# Altium schematic sheet [02] MainBoard.SchDoc
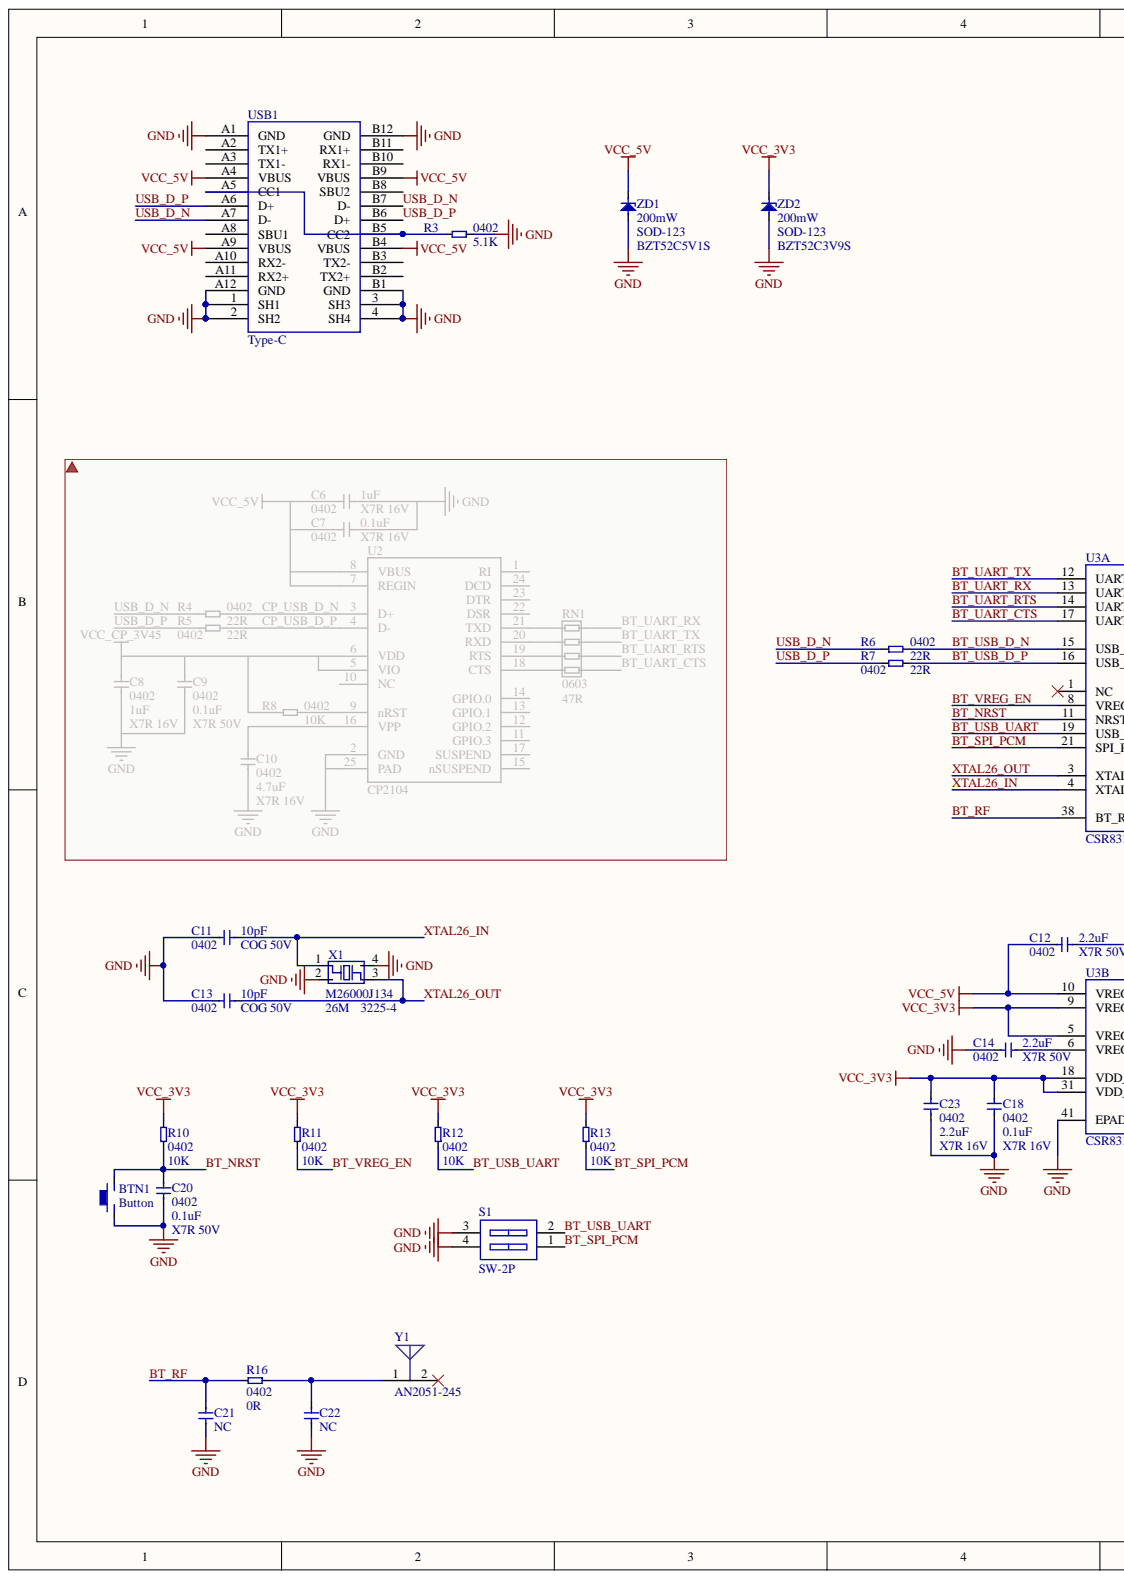
[diagram p0: [02] MainBoard.SchDoc, left]
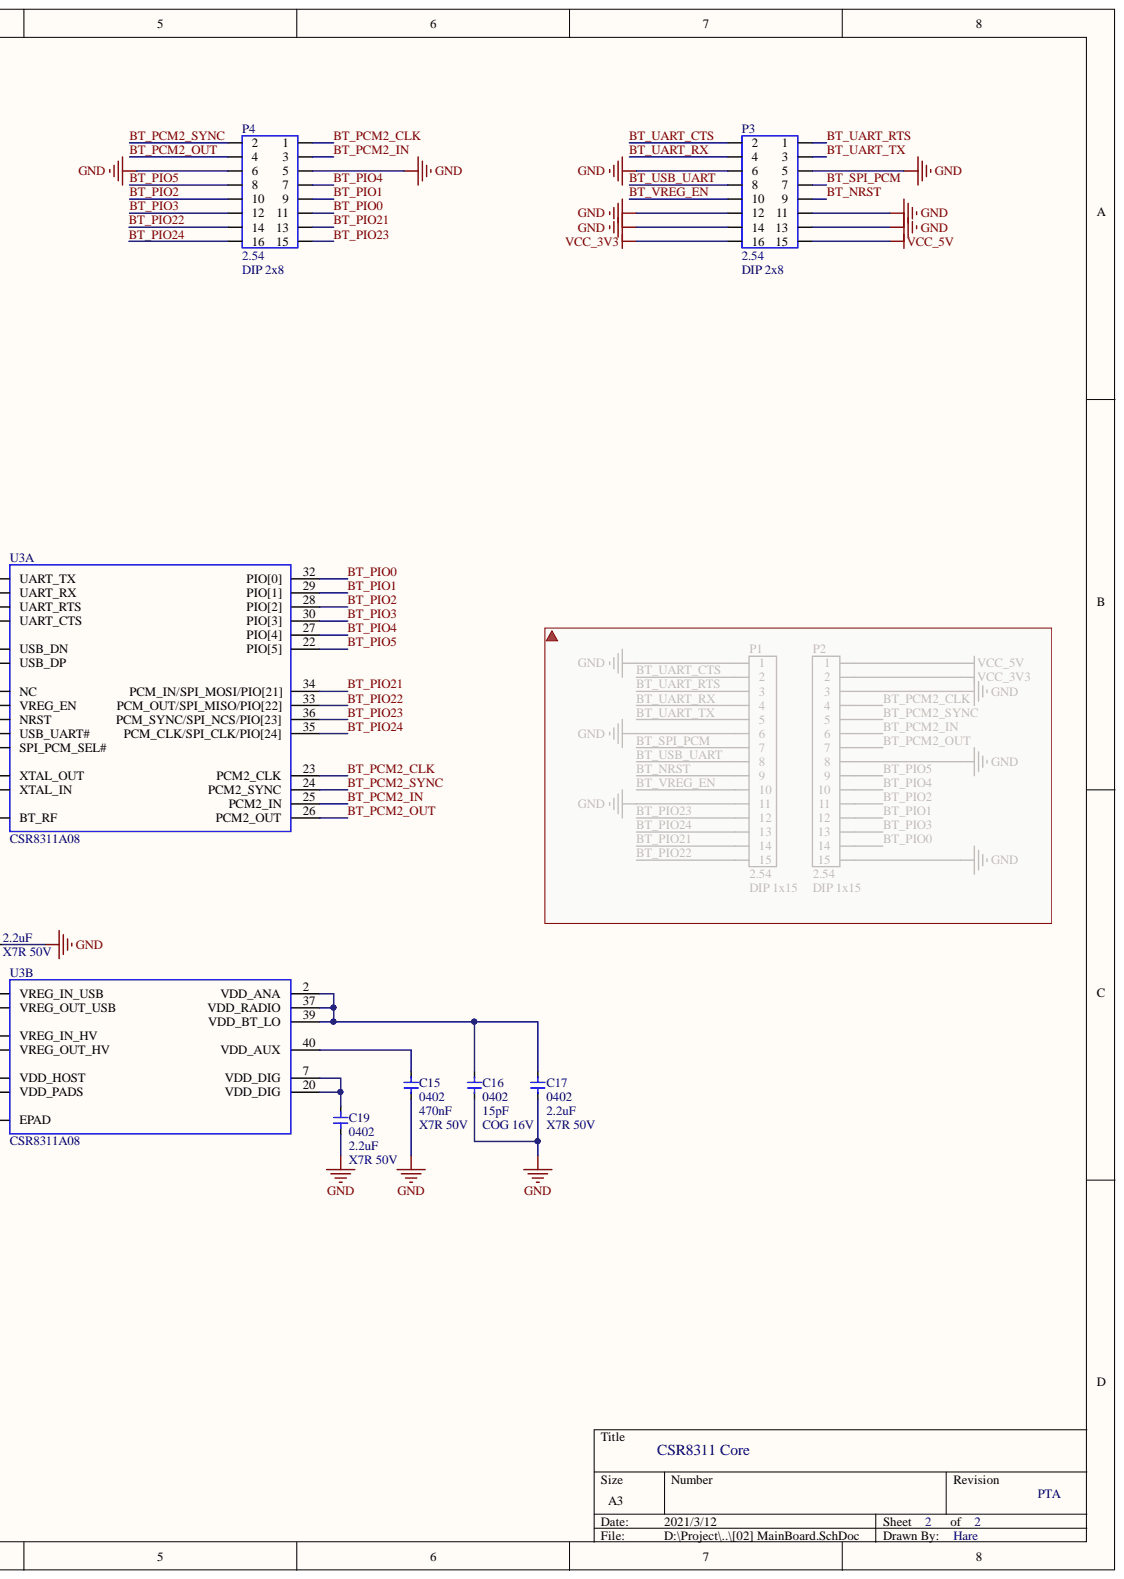
[diagram p1: [02] MainBoard.SchDoc, right]

## Parts
BTN1  Button  [BTN-4P-4.2*3.4*2.5]
C11  10pF  Specification: COG 50V  [0402]
C12  2.2uF  [0402]
C13  10pF  Specification: COG 50V  [0402]
C14  2.2uF  [0402]
C15  470nF  [0402]
C16  15pF  [0402]
C17  2.2uF  Specification: X7R 50V  [0402]
C18  0.1uF  Specification: X7R 16V  [0402]
C19  2.2uF  Specification: X7R 50V  [0402]
C20  0.1uF  Specification: X7R 50V  [0402]
C21  NC  [0402]
C22  NC  [0402]
C23  2.2uF  [0402]
P3  DIP 2x8  Type: 2.54  [HDR2X8_2.54_180]
P4  DIP 2x8  Type: 2.54  [HDR2X8_2.54_180]
R3  5.1K  [0402]
R6  22R  [0402]
R7  22R  [0402]
R10  10K  [0402]
R11  10K  [0402]
R12  10K  [0402]
R13  10K  [0402]
R16  0R  [0402]
S1  SW-2P  [SW-SMD-2-1.27]
U3  CSR8311A08  [QFN-40-P0.5]  (parts A,B)
USB1  Type-C  [USB-Type-C]
X1  M26000J134  Specification: 26M  [3225-4]
Y1  AN2051-245  [ANT_2.4G_5.1X2.0]
ZD1  BZT52C5V1S  Specification: 200mW  [SOD-123]
ZD2  BZT52C3V9S  Specification: 200mW  [SOD-123]

## Nets
GND: BTN1.1 C11.1 C12.2 C13.1 C14.1 C15.2 C16.2 C17.2 C18.1 C19.2 C20.2 C21.1 C22.1 C23.2 P3.5 P3.6 P3.11 P3.12 P3.13 P3.14 P4.5 P4.6 R3.1 S1.3 S1.4 U3.41(EPAD) USB1.1(SH1) USB1.2(SH2) USB1.3(SH3) USB1.4(SH4) USB1.A1(GND) USB1.A12(GND) USB1.B1(GND) USB1.B12(GND) X1.2 X1.4 ZD1.1 ZD2.1
VCC_3V3: C18.2 C23.1 P3.16 R10.1 R11.1 R12.1 R13.1 U3.5(VREG_IN_HV) U3.9(VREG_OUT_USB) U3.18(VDD_HOST) U3.31(VDD_PADS) ZD2.2
VCC_5V: C12.1 P3.15 U3.10(VREG_IN_USB) USB1.A4(VBUS) USB1.A9(VBUS) USB1.B4(VBUS) USB1.B9(VBUS) ZD1.2
BT_NRST: BTN1.2 C20.1 P3.9 R10.2 U3.11(NRST)
BT_PCM2_CLK: P4.1 U3.23(PCM2_CLK)
BT_PCM2_IN: P4.3 U3.25(PCM2_IN)
BT_PCM2_OUT: P4.4 U3.26(PCM2_OUT)
BT_PCM2_SYNC: P4.2 U3.24(PCM2_SYNC)
BT_PIO0: P4.11 U3.32(PIO[0])
BT_PIO1: P4.9 U3.29(PIO[1])
BT_PIO2: P4.10 U3.28(PIO[2])
BT_PIO3: P4.12 U3.30(PIO[3])
BT_PIO4: P4.7 U3.27(PIO[4])
BT_PIO5: P4.8 U3.22(PIO[5])
BT_PIO21: P4.13 U3.34(PCM_IN/SPI_MOSI/PIO[21])
BT_PIO22: P4.14 U3.33(PCM_OUT/SPI_MISO/PIO[22])
BT_PIO23: P4.15 U3.36(PCM_SYNC/SPI_NCS/PIO[23])
BT_PIO24: P4.16 U3.35(PCM_CLK/SPI_CLK/PIO[24])
BT_RF: C21.2 R16.1 U3.38(BT_RF)
BT_SPI_PCM: P3.7 R13.2 S1.1 U3.21(SPI_PCM_SEL#)
BT_UART_CTS: P3.2 U3.17(UART_CTS)
BT_UART_RTS: P3.1 U3.14(UART_RTS)
BT_UART_RX: P3.4 U3.13(UART_RX)
BT_UART_TX: P3.3 U3.12(UART_TX)
BT_USB_D_N: R6.1 U3.15(USB_DN)
BT_USB_D_P: R7.1 U3.16(USB_DP)
BT_USB_UART: P3.8 R12.2 S1.2 U3.19(USB_UART#)
BT_VREG_EN: P3.10 R11.2 U3.8(VREG_EN)
USB_D_N: R6.2 USB1.A7(D-) USB1.B7(D-)
USB_D_P: R7.2 USB1.A6(D+) USB1.B6(D+)
XTAL26_IN: C11.2 U3.4(XTAL_IN) X1.1
XTAL26_OUT: C13.2 U3.3(XTAL_OUT) X1.3
NetC14_2: C14.2 U3.6(VREG_OUT_HV)
NetC15_1: C15.1 U3.40(VDD_AUX)
NetC16_1: C16.1 C17.1 U3.2(VDD_ANA) U3.37(VDD_RADIO) U3.39(VDD_BT_LO)
NetC19_1: C19.1 U3.7(VDD_DIG) U3.20(VDD_DIG)
NetC22_2: C22.2 R16.2 Y1.1
NetR3_2: R3.2 USB1.A5(CC1) USB1.B5(CC2)

Not connected: U3 1(NC); USB1 A2(TX1+) A3(TX1-) A8(SBU1) A10(RX2-) A11(RX2+) B2(TX2+) B3(TX2-) B8(SBU2) B10(RX1-) B11(RX1+); Y1 2

## Library data of the parts
C11 C13 C17-C20 = CAP: Capacitor; Component Kind: Standard; Library Name: SCH_General.SchLib
  C11 C13: Value: 10pF
  C17 C19: Value: 2.2uF
  C18 C20: Value: 0.1uF
C12 C14 C15 = CAP: Capacitor; Specification: X7R 50V; Component Kind: Standard; Library Name: SCH_General.SchLib
  C12 C14: Value: 2.2uF
  C15: Value: 470nF
C16 = CAP: Capacitor; Specification: COG 16V; Component Kind: Standard; Library Name: SCH_General.SchLib; Value: 15pF
C21-C23 = CAP: Capacitor; Specification: X7R 16V; Component Kind: Standard; Library Name: SCH_General.SchLib
  C21 C22: Value: NC
  C23: Value: 2.2uF
P3 P4 = HDR2x8: Header; Component Kind: Standard; Library Name: SCH_ConnectorHDR.SchLib; Value: DIP 2x8
R3 R6 R7 R10-R13 R16 = RES: Resistor; Specification: ±1%; Component Kind: Standard; Library Name: SCH_General.SchLib
  R3: Value: 5.1K
  R6 R7: Value: 22R
  R10-R13: Value: 10K
  R16: Value: 0R
S1 = SW-2P: 拨码开关2P; Component Kind: Standard; Library Name: SCH_Switch.SchLib
U3 = CSR8311: Bluetooth v4.1 single-chip radio and baseband IC for automotive applications.; Component Kind: Standard; Library Name: SCH_RF.SchLib
USB1 = USB-Type-C: Component Kind: Standard; Library Name: SCH_Connector.SchLib
X1 = XTAL-4P: Component Kind: Standard; Library Name: SCH_General.SchLib; Value: M26000J134
Y1 = ANTENNA: Component Kind: Standard; Library Name: SCH_RF.SchLib
ZD1 ZD2 = Diode-ZD: Zender Diode; Component Kind: Standard; Library Name: SCH_General.SchLib
  ZD1: Value: BZT52C5V1S
  ZD2: Value: BZT52C3V9S
BTN1 = Button: Component Kind: Standard; Description: Button; Library Name: SCH_General.SchLib
